# Altium schematic sheet 01-ACI-Power-Unit-HV00.00.SchDoc(01-ACI-Power-Unit-HV00.00)
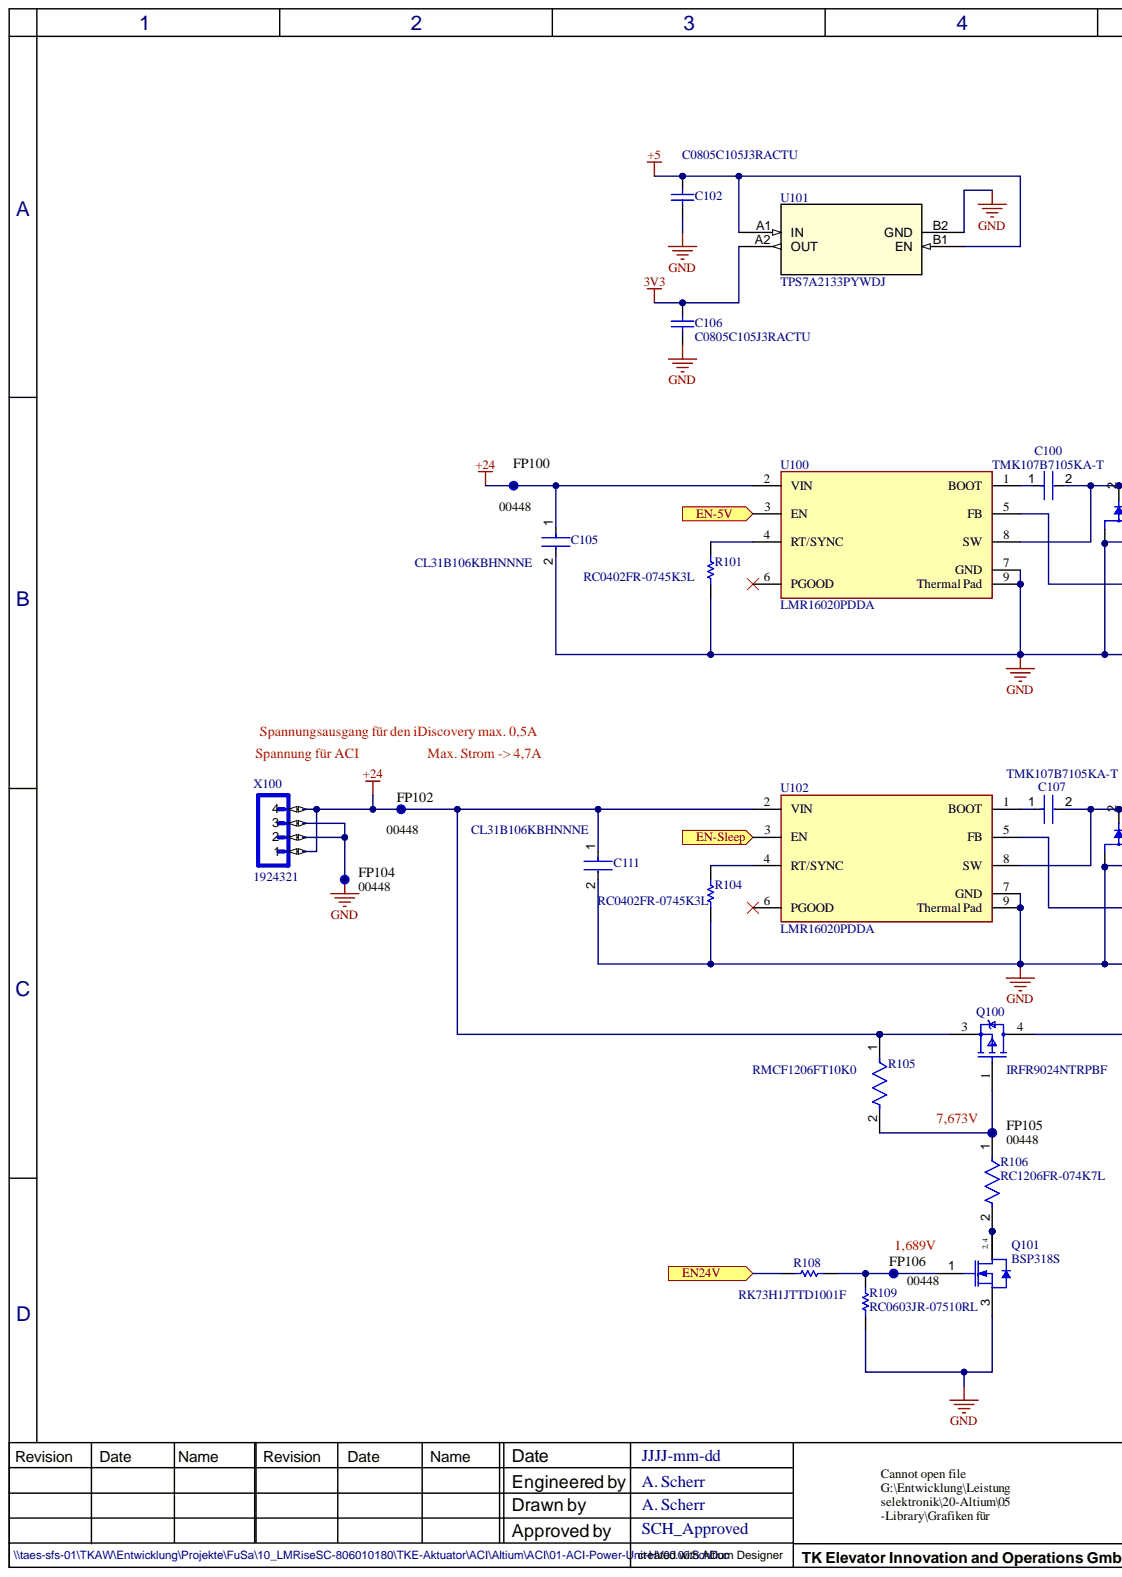
[diagram p0: 01-ACI-Power-Unit-HV00.00.SchDoc, left]
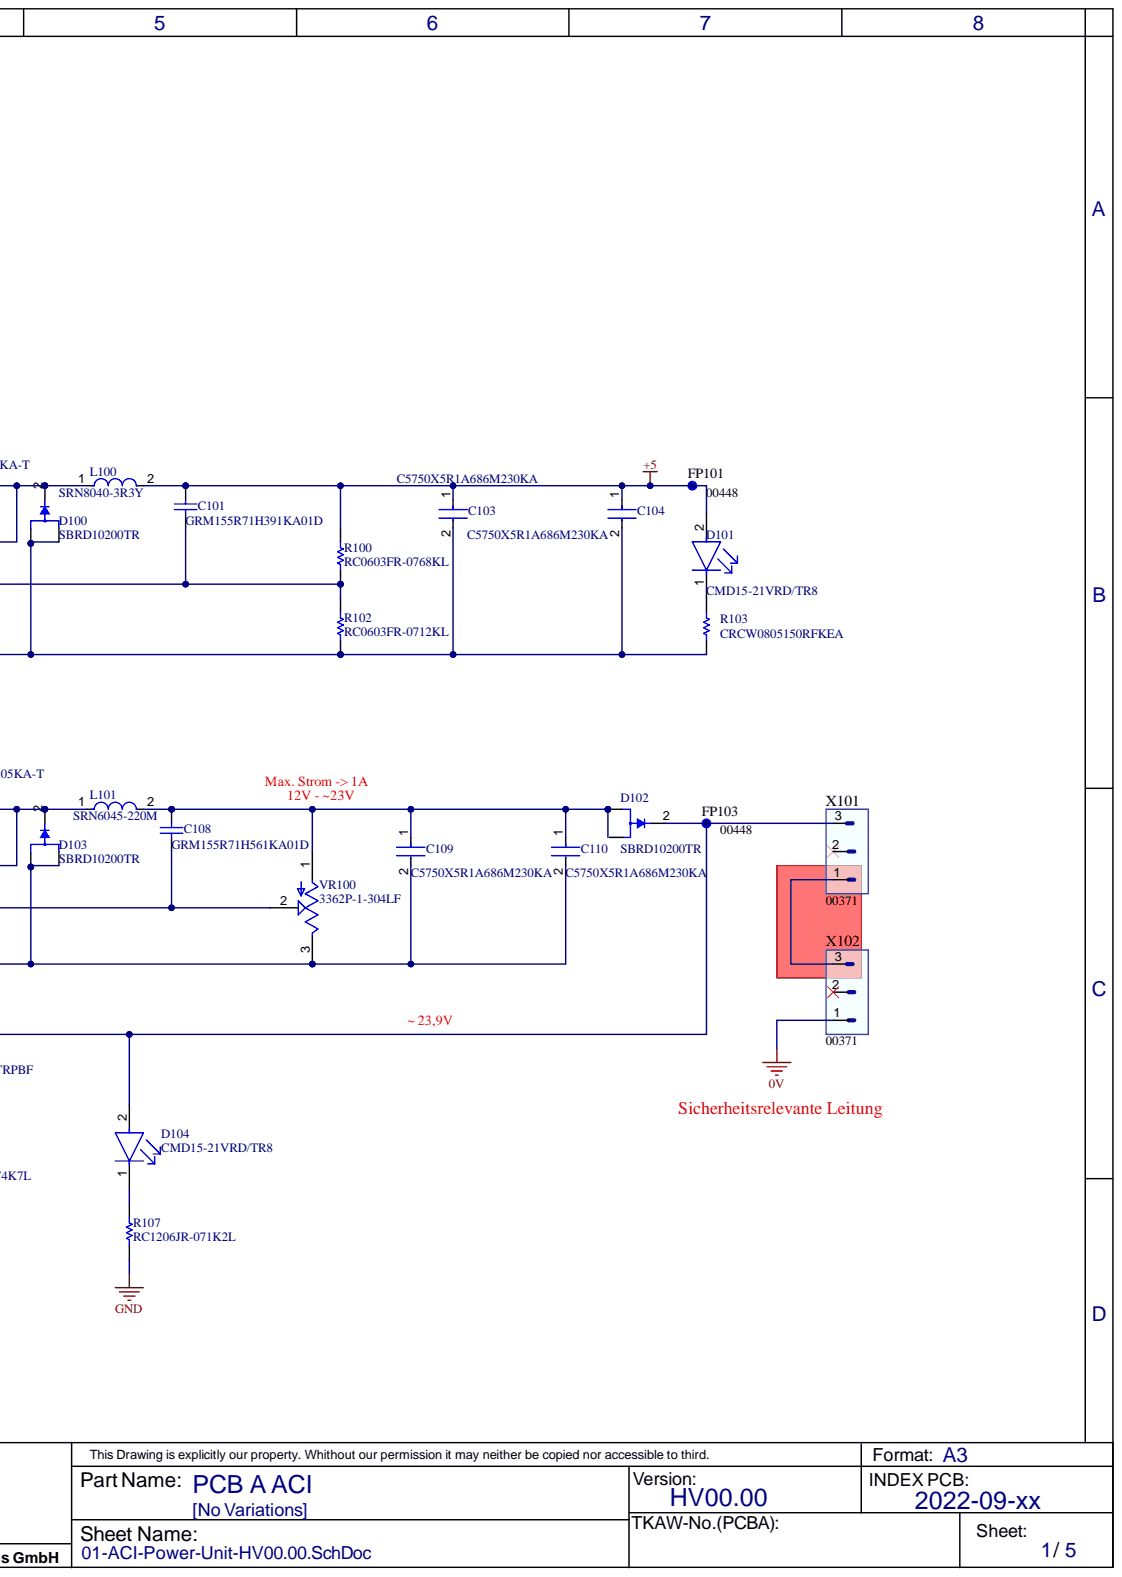
[diagram p1: 01-ACI-Power-Unit-HV00.00.SchDoc, right]

## Parts
C100  TMK107B7105KA-T  [FP-0603-L_1_6_0_1-W_0_8_0-IPC_B]
C101  GRM155R71H391KA01D  [CAPC1005X55X25LL05T10]
C102  C0805C105J3RACTU  [CAPC2013X145X50NL20T25]
C103  C5750X5R1A686M230KA  [FP-C5750-230-0_4-IPC_C]
C104  C5750X5R1A686M230KA  [FP-C5750-230-0_4-IPC_C]
C105  CL31B106KBHNNNE  [FP-CL31B106KBHNNNE-MFG]
C106  C0805C105J3RACTU  [CAPC2013X145X50NL20T25]
C107  TMK107B7105KA-T  [FP-0603-L_1_6_0_1-W_0_8_0-IPC_B]
C108  GRM155R71H561KA01D  [CAPC1005X55X25LL05T10]
C109  C5750X5R1A686M230KA  [FP-C5750-230-0_4-IPC_C]
C110  C5750X5R1A686M230KA  [FP-C5750-230-0_4-IPC_C]
C111  CL31B106KBHNNNE  [FP-CL31B106KBHNNNE-MFG]
D100  SBRD10200TR  [DPAK228P1005X240-4N]
D101  CMD15-21VRD/TR8  [FP-CMD15-21-MFG]
D102  SBRD10200TR  [DPAK228P1005X240-4N]
D103  SBRD10200TR  [DPAK228P1005X240-4N]
D104  CMD15-21VRD/TR8  [FP-CMD15-21-MFG]
FP100  00448  [PRODAPP_Testpunkt_Top_d1.8mm]  (no BOM)
FP101  00448  [PRODAPP_Testpunkt_Top_d1.8mm]  (no BOM)
FP102  00448  [PRODAPP_Testpunkt_Top_d1.8mm]  (no BOM)
FP103  00448  [PRODAPP_Testpunkt_Top_d1.8mm]  (no BOM)
FP104  00448  [PRODAPP_Testpunkt_Top_d1.8mm]  (no BOM)
FP105  00448  [PRODAPP_Testpunkt_Top_d1.8mm]  (no BOM)
FP106  00448  [PRODAPP_Testpunkt_Top_d1.8mm]  (no BOM)
L100  SRN8040-3R3Y  [FP-SRN8040-MFG]
L101  SRN6045-220M  [FP-SRN6045-MFG]
Q100  IRFR9024NTRPBF  [FP-PG-TO252-3-MFG]
Q101  BSP318S  [INF-PG-SOT223_SC-73_M]
R100  RC0603FR-0768KL  [RESC1608X55X25LL10T15]
R101  RC0402FR-0745K3L  [RESC1005X40X25ML05T10]
R102  RC0603FR-0712KL  [RESC1608X55X25NL10T15]
R103  CRCW0805150RFKEA  [RESC2013X50X30ML20T20]
R104  RC0402FR-0745K3L  [RESC1005X40X25ML05T10]
R105  RMCF1206FT10K0  [FP-RMCF1206-MFG]
R106  RC1206FR-074K7L  [FP-RC1206-0_65-IPC_B]
R107  RC1206JR-071K2L  [RESC1206(3216)_M]
R108  RK73H1JTTD1001F  [RESC1608X55X30LL20T10]
R109  RC0603JR-07510RL  [RESC1608X55X25LL10T15]
U100  LMR16020PDDA  [FP-DDA0008E-IPC_C]
U101  TPS7A2133PYWDJ  [POWERWCSP4_YWD_TEX]
U102  LMR16020PDDA  [FP-DDA0008E-IPC_C]
VR100  3362P-1-304LF  [TRIM_3362P-1-304LF]
X100  1924321  [1924321]
X101  00371  [CON THR_Combicon_male 3pol_str_pitch_5.08mm]
X102  00371  [CON THR_Combicon_male 3pol_str_pitch_5.08mm]

## Ports
EN24V, EN-5V, EN-Sleep

## Nets
0V: X102.1
3V3: C106.1 U101.A2(OUT)
+5: C101.1 C102.1 C103.1 C104.1 D101.2 FP101.1 L100.2 R100.1 U101.A1(IN) U101.B1(EN)
+24: C105.1 C111.1 FP100.1 FP102.1 Q100.3 R105.1 U100.2(VIN) U102.2(VIN) X100.P$1 X100.P$4
GND: C102.2 C103.2 C104.2 C105.2 C106.2 C109.2 C110.2 C111.2 D100.1 D100.3 D103.1 D103.3 FP104.1 Q101.3 R101.2 R102.2 R103.2 R104.2 R107.2 R109.2 U100.7(GND) U100.9(Thermal_Pad) U101.B2(GND) U102.7(GND) U102.9(Thermal_Pad) VR100.3 X100.P$2 X100.P$3
EN24V: R108.1 ; port EN24V
EN-5V: U100.3(EN) ; port EN-5V
EN-Sleep: U102.3(EN) ; port EN-Sleep
NetC100_1: C100.1 U100.1(BOOT)
NetC100_2: C100.2 D100.2 L100.1 U100.8(SW)
NetC101_2: C101.2 R100.2 R102.1 U100.5(FB)
NetC107_1: C107.1 U102.1(BOOT)
NetC107_2: C107.2 D103.2 L101.1 U102.8(SW)
NetC108_1: C108.1 C109.1 C110.1 D102.1 L101.2 VR100.1
NetC108_2: C108.2 U102.5(FB) VR100.2
NetD101_1: D101.1 R103.1
NetD102_2: D102.2 D104.2 FP103.1 Q100.4 X101.3
NetD104_1: D104.1 R107.1
NetFP105_1: FP105.1 Q100.1 R105.2 R106.1
NetFP106_1: FP106.1 Q101.1 R108.2 R109.1
NetQ101_2: Q101.2 Q101.4 R106.2
NetR101_1: R101.1 U100.4(RT/SYNC)
NetR104_1: R104.1 U102.4(RT/SYNC)
NetX101_1: X101.1 X102.3

Not connected: D102 3; U100 6(PGOOD); U102 6(PGOOD); X101 2; X102 2

## Library data of the parts
C100 C107 = CMP-2000-07259-3: None; Capacitance: 1uF; Dielectric: X7R; Tolerance: 10%; Voltage Rating: 25V; Case Code (Imperial): 0603; Case Code (Metric): 1608; Case/Package: 0603; Component Kind: Standard; Construction: Flat; Contact Plating: Tin; Depth: 0.8mm; Halogen Free: Halogen Free; Height: 0.8mm; Length: 1.6mm; Library Name: Altium Content Vault; Max Operating Temperature: 125°C; Min Operating Temperature: -55°C; Mount: Surface Mount; Number of Pins: 2; Package Quantity: 1; Packaging: Tape and Reel; Radiation Hardening: No; REACH SVHC: No SVHC; Resistor Type: Ceramic; RoHS Compliant: Yes; Series: M; Simulation: TMK107B7105_A-T; Termination: SMD/SMT; Thickness: 0.035inch; Voltage Rating (DC): 25V; Width: 0.031inch
C101 = CMP-2008-03942-1: Manufacturer: Murata; Component Kind: Standard; Library Name: Altium Content Vault; Part Number: GRM155R71H391KA01D
C102 C106 = CMP-2007-01121-1: Manufacturer: Kemet; Component Kind: Standard; Library Name: Altium Content Vault; Part Number: C0805C105J3RACTU
C103 C104 C109 C110 = CMP-08246-004950-1: Multilayer Ceramic Capacitors 68uF ±20% 10V X5R SMD 2220; Capacitance: 68uF; Case Code (Imperial): 2220; Case Code (Metric): 5750; Case/Package: 2220; Component Kind: Standard; Construction: Flat; Depth: 5mm; Dielectric: X5R; Dielectric Material: Ceramic; Height: 2.3mm; Lead Free: Lead Free; Length: 5.7mm; Library Name: Altium Content Vault; Max Operating Temperature: 85°C; Min Operating Temperature: -55°C; Mount: Surface Mount; Number of Pins: 2; Package Quantity: 1; Packaging: Tape and Reel; Radiation Hardening: No; REACH SVHC: No SVHC; Resistor Type: Ceramic; RoHS Compliant: Yes; Series: C; Termination: SMD/SMT; Thickness: 0.098inch; Tolerance: 20%; Voltage: 10V; Voltage Rating: 10V; Voltage Rating (DC): 10V; Weight: 0.016932oz; Width: 0.197inch
C105 C111 = CMP-2000-07530-2: CAP CER 10UF 50V X7R 1206; Manufacturer: Samsung; Material: Ceramic; Temperature Coefficient: 15%; Tolerance: 10%; Automotive: No; Capacitance: 10uF; Category: Cap; Component Kind: Standard; ComponentLink2Description: Datasheet; ComponentLink2URL: http://www.samsungsem.com/global/front/downloadcms.do?path=%2F%2Fglobal%2F%2Fsupport%2F%2Fproduct-search%2F%2Fmlcc%2F%2F__icsFiles%2F%2Fafieldfile%2F%2F2019%2F%2F06%2F%2F18&fileName=CL31B106KBHNNNE-19.pdf; Device Class L1: Passive Components; Device Class L2: Capacitors; Device Class L3: Ceramic Capacitors; Digikey Description: CAP CER 10UF 50V X7R 1206; Height: 1.8mm; Lead Free: Yes; Library Name: Altium Content Vault; Manufacturer Part Number: CL31B106KBHNNNE; Octopart: https://octopart.com/cl31b106kbhnnne-samsung-30507377; Package: CL31B106KBHNNNE; RoHS: TRUE; Temperature: -55°C to +125°C; Temperature Characteristic: X7R; Temperature Range High: +125°C; Temperature Range Low: -55°C; Value: 10uF; Voltage Rating: 50V
C108 = CMP-2008-04664-1: Manufacturer: Murata; Component Kind: Standard; Library Name: Altium Content Vault; Part Number: GRM155R71H561KA01D
D100 D102 D103 = SBRD10200TR: SBRD10200 Series 200 V 10 A Surface Mount Schottky Rectifier - TO-252-3; Availability: Unavailable; Component Kind: Standard; Library Name: SBRD10200TR.IntLib; MP: SBRD10200TR; Package: None; Price: None
D101 D104 = CMP-53976-000002-1: LED RED DIFFUSED 1206 SMD; Manufacturer: VCC; Forward Current: 20mA; Forward Voltage: 2V; Led Orientation: Top View; Luminous Intensity: 4mcd; Peak Emmision Wavelength: 640nm; Power Dissipation: 105mW; Reverse Voltage: 5V; Automotive: No; Category: Diode; Colour: Red; Component Kind: Standard; ComponentLink2Description: Datasheet; ComponentLink2URL: https://www.vcclite.com/wp-content/uploads/wpallimport/files/files/CMD1521SeriesRev1.pdf; Device Class L1: Optoelectronics; Device Class L2: LEDs; Device Class L3: unset; Digikey Description: LED RED DIFFUSED 1206 SMD; Height: 1.3mm; Lead Free: Yes; Library Name: Altium Content Vault; Manufacturer Part Number: CMD15-21VRD/TR8; Mouser Description: Standard LEDs - SMD RED DIFFUSED; Octopart: https://octopart.com/cmd15-21vrd%2Ftr8-vcc-26668835; Package: CMD15-21; RoHS: TRUE; Temperature: -40°C to +85°C; Temperature Range High: +85°C; Temperature Range Low: -40°C
FP100-FP106 = CMP-015-000167-1: PartCategory: 095-Production Appendix; PartValue: d1.8mm; PartVariation: TOP SMD Pad; BOMSortNo: not in BOM; TKAW No: X000 000 00448; Description: 00448; Ident: 00448; PartType: Testpoint; Library Name: TK Elevator
L100 = CMP-07248-000074-1: FIXED IND 3.3UH 5.6A 21 MOHM SMD; Core Material: Ferrite; DC Resistance (DCR): 21mR; Inductance Tolerance: 30%; Material: Steel; Sample Rate (Hz): 100kHz; Saturation Current: 5.5A; Self Resonant Frequency: 34MHz; Shielding: Shielded; Case/Package: Nonstandard; Component Kind: Standard; Current Rating: 5.6A; DC Current: 5.6A; Depth: 8.3mm; Height: 4mm; Height - Seated (Max): 0.157inch; Inductance: 3.3uH; Length: 8mm; Library Name: Altium Content Vault; Max DC Current: 5.6A; Max Operating Temperature: 125°C; Military Standard: Not; Min Operating Temperature: -40°C; Mount: Surface Mount; Number of Pins: 2; Package Quantity: 1000; Packaging: Tape and Reel; REACH SVHC: No SVHC; Resistor Type: Wirewound; RMS Current (Irms): 5.6A; RoHS Compliant: Yes; Series: SRN8040; Termination: SMD/SMT; Test Frequency: 100kHz; Tolerance: 30%; Width: 8mm
L101 = CMP-07248-000059-1: FIXED IND 22UH 1.5A 142 MOHM SMD; Core Material: Ferrite; DC Resistance (DCR): 142mR; Inductance Tolerance: 20%; Material: Steel; Sample Rate (Hz): 100kHz; Saturation Current: 1.9A; Self Resonant Frequency: 11MHz; Shielding: Shielded; Case/Package: Nonstandard; Component Kind: Standard; Current Rating: 1.5A; DC Current: 1.5A; Depth: 6.2mm; Height: 4.5mm; Height - Seated (Max): 0.177inch; Inductance: 22uH; Length: 6mm; Library Name: Altium Content Vault; Max DC Current: 1.5A; Max Operating Temperature: 125°C; Military Standard: Not; Min Operating Temperature: -40°C; Mount: Surface Mount; Number of Pins: 2; Package Quantity: 1000; Packaging: Tape and Reel; REACH SVHC: No SVHC; Resistor Type: Wirewound; RMS Current (Irms): 1.5A; RoHS Compliant: Yes; Series: SRN6045; Termination: SMD/SMT; Test Frequency: 100kHz; Tolerance: 20%; Weight: 0.027725oz; Width: 6mm
Q100 = CMP-32901-000043-2: MOSFET P-CH 55V 11A DPAK; Drain to Source Breakdown Voltage: -55V; Drain to Source Resistance: 175mR; Gate to Source Voltage (Vgs): 20V; Input Capacitance: 350pF; Max Power Dissipation: 38W; Rise Time: 55ns; Threshold Voltage: -4V; Turn-Off Delay Time: 23ns; Turn-On Delay Time: 13ns; Case/Package: TO-252-3; Component Kind: Standard; Contact Plating: Tin; Continuous Drain Current (ID): 11A; Drain to Source Voltage (Vdss): -55V; Halogen Free: Halogen Free; Height: 0.094inch; Lead Free: Contains Lead; Library Name: Altium Content Vault; Max Dual Supply Voltage: -55V; Max Operating Temperature: 150°C; Min Operating Temperature: -55°C; Mount: Surface Mount; Number of Pins: 3; Packaging: Tape and Reel; Power Dissipation: 38W; Rds On Max: 175mR; REACH SVHC: No SVHC; RoHS Compliant: Yes; Width: 0.245inch
Q101 = CMP-1149-00015-1: N-Channel SIPMOS Small-Signal-Transistor, 60 V VDS, 2.6 A ID, -55 to 150 degC, PG-SOT223 (SC-73), Reel, Green; Manufacturer: Infineon; Green: TRUE; ID (max): 2.6Â A; IDpuls (max): 10.4Â A; Mode: Enhancement; Polarity: N; Ptot (max): 1.8Â W; QG: 14 nC; RDS (on) (max): 90 mOhm; RDS (on) (max) (@4.5V): 150 mOhm; VDS (max): 60 V; VGS(th) (max): 2 V; VGS(th) (min): 1.2Â V; Component Kind: Standard; ComponentLink1Description: Manufacturer URL; ComponentLink1URL: http://www.infineon.com/; ComponentLink2Description: Datasheet; ComponentLink2URL: http://www.infineon.com/dgdl/BSP318S_Rev2.4._.pdf?folderId=db3a3043156fd573011622e10b5c1f67&fileId=db3a30433b47825b013b51c9a6fc2aa8; ComponentLink3Description: Package Specification; ComponentLink3URL: http://www.infineon.com/dgdl/SOT223-Package_Overview.pdf?folderId=db3a30431936bc4b0119530470b03c3d&fileId=db3a30431936bc4b0119531d40b93c5c; DatasheetVersion: Rev. 2.4; Halogen-free: TRUE; Library Name: Altium Content Vault; Mounting Technology: Surface Mount; PackageDescription: 4-Pin Small Outline Transistor Package, 6.5 x 3.5 mm Body, 2.3 mm Pitch; PackageReference: PG-SOT223 (SC-73); PackageVersion: Apr-08; Packing: Reel; PartNumber: BSP318S
R100 = CMP-2000-06944-1: Chip Resistor, 68 KOhm, +/- 1%, 0.1 W, -55 to 155 degC, 0603 (1608 Metric), RoHS, Tape and Reel; Manufacturer: Yageo; Power Rating: 100mW; Resistance: 68kOhms; Temperature Coefficient: 100PPM/C; Voltage Rating: 50V; Case Code - in: 0603; Case Code - mm: 1608; Component Kind: Standard; Datasheet URL: http://www.yageo.com/documents/recent/PYu-RC_Group_51_RoHS_L_7.pdf; Datasheet Version: Rev. 7, 05/2017; Library Name: Altium Content Vault; Manufacturer URL: http://www.yageo.com/; Mounting Technology: SurfaceMount; Operating Temperature Range: -55Cto+155C; Package Description: 2-Pin Surface Mount Device, Body 1.6 x 0.8 mm; Package Reference: 0603; Packaging: Reel; Product: ThickFilmResistorsGeneralPurpose; Series: RC; Termination Style: SMD/SMT; Tolerance: 1%
R101 R104 = CMP-2002-07692-1: Manufacturer: Yageo / Phycomp; Component Kind: Standard; Library Name: Altium Content Vault; Part Number: RC0402FR-0745K3L
R102 = CMP-1659-00047-1: Chip Resistor, 12 KOhm, +/- 1%, 100 mW, -55 to 155 degC, 0603 (1608 Metric), RoHS, Tape and Reel; Case\Package: 0603; Power Rating: 100 mW; Resistance: 220 kohm; Voltage Rating: 50 V; Case Code (Imperial): 0603; Case Code (Metric): 1608; Component Kind: Standard; ComponentLink1Description: Manufacturer URL; ComponentLink1URL: http://www.yageo.com/; ComponentLink2Description: Datasheet; ComponentLink2URL: http://www.yageo.com/documents/recent/PYu-RC_Group_51_RoHS_L_04.pdf; DatasheetVersion: Rev. 4, 02/2016; Height: 0.45 mm; Length: 1.6 mm; Library Name: Altium Content Vault; Max Operating Temperature: 155 degC; Min Operating Temperature: -55 degC; Mount: Surface Mount; Package Quantity: 5000; PackageDescription: 2-Pin Surface Mount Device, Body 1.6 x 0.8 mm; PackageReference: 0603; Packaging: Tape and Reel; Pins: 2; REACH SVHC: No SVHC; RoHSCompliant: true; Tolerance: 1%; Voltage Rating (DC): 50 V; Width: 0.8 mm
R103 = CMP-2001-04962-1: Manufacturer: Vishay Dale; Component Kind: Standard; Library Name: Altium Content Vault; Part Number: CRCW0805150RFKEA
R105 = CMP-26527-000151-1: 10kΩ ±1% 0.25W 1206 Thick Film Chip Resistor AEC-Q200 compliant; Max Power Dissipation: 250mW; Resistance: 10kR; Temperature Coefficient: 100ppm/°C; Case Code (Imperial): 1206; Case Code (Metric): 3216; Case/Package: 1206; Component Kind: Standard; Construction: Rectangular; Contact Plating: Tin; Depth: 1.6mm; Features: Automotive AEC-Q200; Height: 0.028inch; Lead Free: Lead Free; Length: 3.2mm; Library Name: Altium Content Vault; Max Operating Temperature: 155°C; Military Standard: Not; Min Operating Temperature: -55°C; Mount: Surface Mount; Number of Terminations: 2; Packaging: Tape and Reel; Power Rating: 250mW; Radiation Hardening: No; Resistor Type: Thick Film; RoHS Compliant: Yes; Series: RMCF; Size Code: 1206; Tolerance: 1%; Width: 0.063inch
R106 = CMP-03412-037805-1: RES SMD 4.7K OHM 1% 1/4W 1206; Max Power Dissipation: 250mW; Resistance: 4.7kR; Temperature Coefficient: 100ppm/°C; Voltage Rating: 200V; Case Code (Imperial): 1206; Case Code (Metric): 3216; Case/Package: 1206; Component Kind: Standard; Construction: Rectangular; Depth: 1.6mm; Features: Moisture Resistant; Height: 0.026inch; Lead Free: Lead Free; Length: 0.122inch; Library Name: Altium Content Vault; Max Operating Temperature: 155°C; Military Standard: Not; Min Operating Temperature: -55°C; Number of Pins: 2; Number of Terminations: 2; Package Quantity: 1; Packaging: Tape and Reel; Power Rating: 250mW; Resistance Tolerance: 1%; Resistor Type: Thick Film; RoHS Compliant: Yes; Series: RC; Size Code: 1206; Termination: SMD/SMT; Tolerance: 1%; Voltage Rating (DC): 200V; Width: 0.063inch
R107 = CMP-1014-00076-1: 1K2 0.25W 5% 1206 (3216 Metric)  SMD; Case-EIA: 1206; Case-Metric: 3216; Value: 1200.00 Ohm; Component Kind: Standard; Library Name: Altium Content Vault; Power: 0.25 W; Technology: SMT; Tolerance: 5 %
R108 = CMP-2000-01098-1: Manufacturer: KOA Speer; Component Kind: Standard; Library Name: Altium Content Vault; Part Number: RK73H1JTTD1001F
R109 = CMP-2000-04281-1: Manufacturer: Yageo; Component Kind: Standard; Library Name: Altium Content Vault; Part Number: RC0603JR-07510RL
U100 U102 = CMP-04918-000407-1: IC REG BUCK ADJUSTABLE 2A 8SOPWR; Manufacturer 1: Texas Instruments; Max Input Voltage: 60V; Max Output Voltage: 50V; Min Input Voltage: 4.3V; Min Output Voltage: 800mV; Number of Outputs: 1; Quiescent Current: 40uA; Switching Frequency: 2.5MHz; Component Kind: Standard; Lead Free: Lead Free; Library Name: ACI.SCHLIB; Manufacturer Part Number 1: LMR16020PDDA; Max Operating Temperature: 125°C; Min Operating Temperature: -40°C; Number of Pins: 8; Output Current: 2A; Output Voltage: 50V; REACH SVHC: No SVHC
U101 = TPS7A2133PYWDJ: No Description Available; Mfr_Name: Texas Instruments; Component Kind: Standard; Library Name: TPS7A2133PYWDJ.IntLib; Manufacturer_Part_Number: TPS7A2133PYWDJ; RefDes: RefDes; Type: DEV; Copyright: Copyright (C) 2022 Ultra Librarian. All rights reserved.
VR100 = 3362P-1-304LF: 300 kOhms 0.5W, 1/2W PC Pins Through Hole Trimmer Potentiometer Cermet 1 Turn Top Adjustment; MF: Bourns; Availability: In Stock; Check_prices: https://www.snapeda.com/parts/3362P-1-304LF/Bourns/view-part/559317/?ref=eda; Component Kind: Standard; Library Name: 3362P-1-304LF.IntLib; MP: 3362P-1-304LF; Package: 3362P-1 Bourns Inc.; Price: None; Purchase-URL: https://pricing.snapeda.com/search/part/3362P-1-304LF/?ref=eda; SnapEDA_Link: https://www.snapeda.com/parts/3362P-1-304LF/Bourns/view-part/559317/?ref=snap
X100 = CMP-025-000000-1: 4 Position Terminal Block Header, Male Pins, Shrouded (4 Side) 0.200 (5.08mm) Vertical Through Hole; MF: Phoenix Contact; Availability: Warning; Component Kind: Standard; Component Type: Standard; Design Item ID: 1924321; MP: 1924321; Package: None; Pin Count: 4; Price: None; Purchase-URL: https://pricing.snapeda.com/search/part/1924321/?ref=eda; Source: 1924321.SchLib; Library Name: TK Elevator
X101 X102 = CMP-015-000085-1: PartCategory: 036-Connector THR; PartDescription: Connector THR Combicon male 3pol str pitchd 5.08; PartValue: 3pol str pitch 5.08mm; PartVariation: male; BOMSortNo: 2 03601 050803; created/last change: TKEGermany/Glück; manu no1: Phoenix; order no1: CCVA 2,5/ 3-G-5,08 P26THR NR.1955866; Pitch/Package: 5.08mm; TKAW No: X950 001 00371; Component Kind: Standard; Description: 00371; Ident: 00371; PartType: Combicon; Library Name: TK Elevator
